# Altium schematic sheet DE-280817.SchDoc(DE-280817)
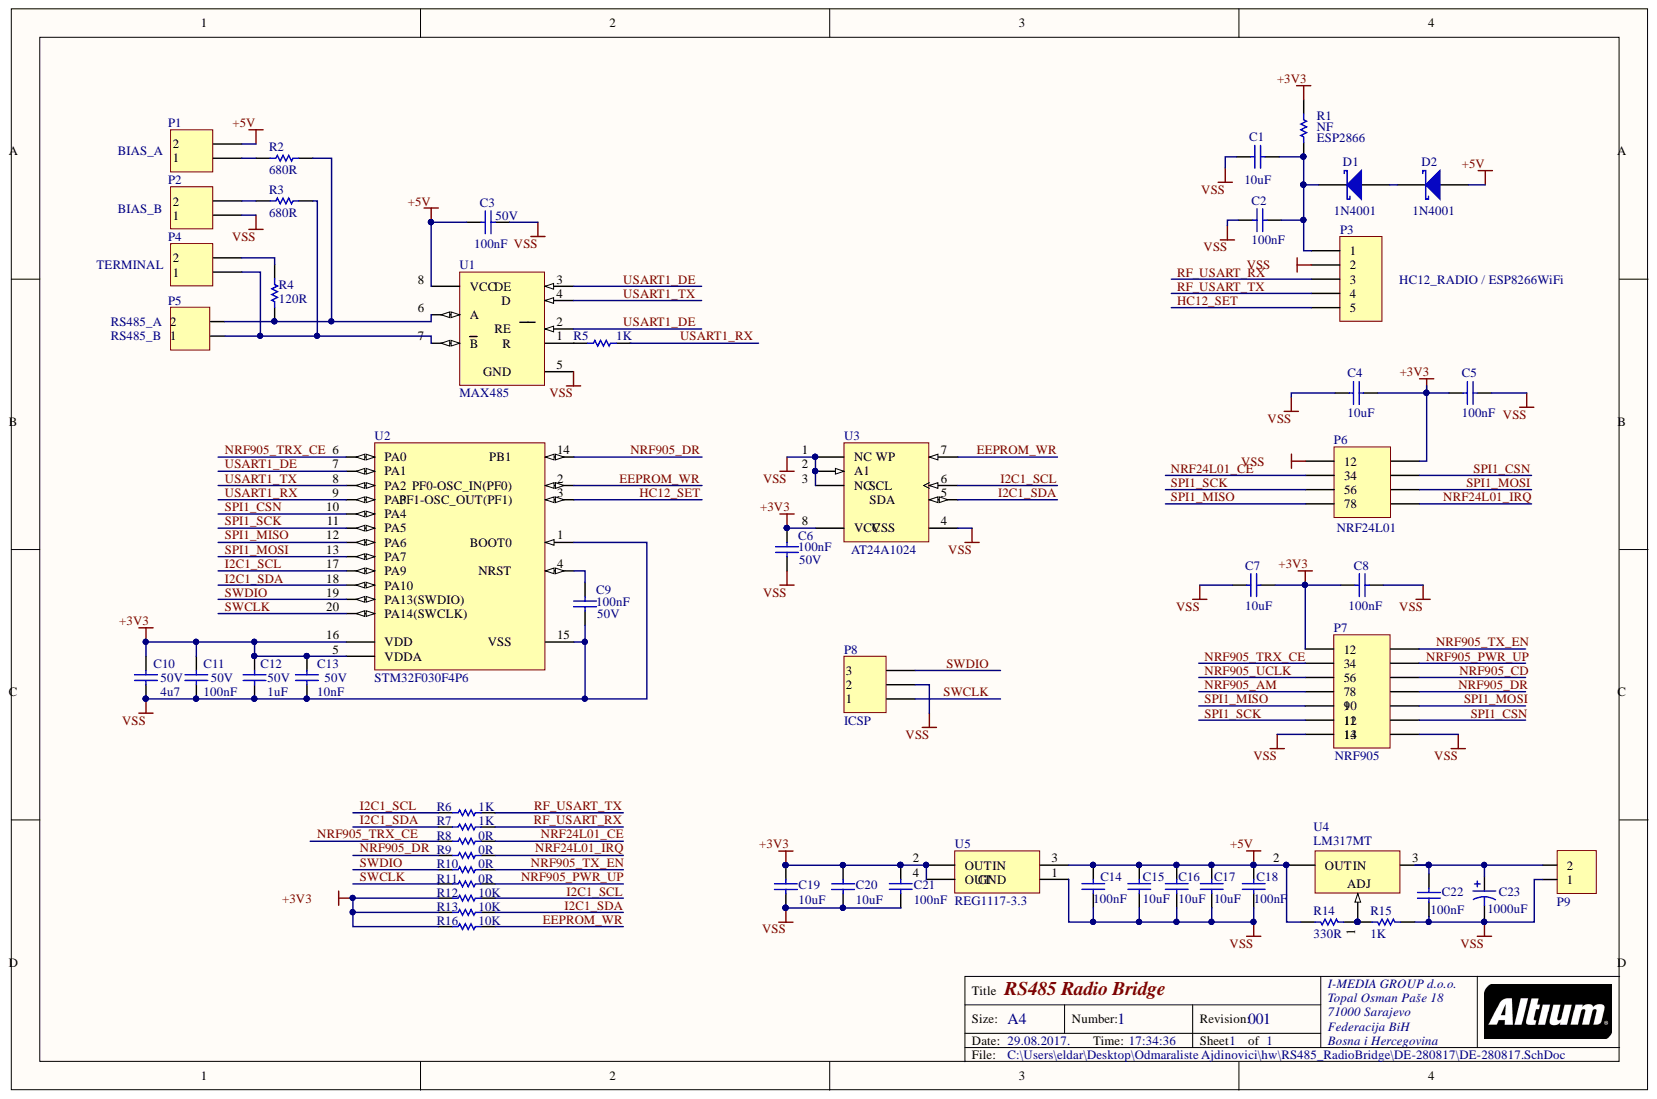
[diagram p0: DE-280817.SchDoc]

## Parts
C1  value 10uF  [0805]  (hidden comment: C0805)
C2  value 100nF  [0603]  (hidden comment: C0603)
C3  50V  value 100nF  [0603]
C4  value 10uF  [0805]  (hidden comment: C0805)
C5  value 100nF  [0603]  (hidden comment: C0603)
C6  50V  value 100nF  [0603]
C7  value 10uF  [0805]  (hidden comment: C0805)
C8  value 100nF  [0603]  (hidden comment: C0603)
C9  50V  value 100nF  [0603]
C10  50V  value 4u7  [0805]
C11  50V  value 100nF  [0603]
C12  50V  value 1uF  [0603]
C13  50V  value 10nF  [0603]
C14  100nF  [0603]
C15  10uF  [0805]
C16  10uF  [0805]
C17  10uF  [0805]
C18  100nF  [0603]
C19  10uF  [0805]
C20  10uF  [0805]
C21  100nF  [0603]
C22  100nF  [0603]
C23  1000uF  [CAPPR5-10x16]
D1  1N4001  [SMA]
D2  1N4001  [SMA]
P1  BIAS_A  [HDR1X2]
P2  BIAS_B  [HDR1X2]
P3  HC12_RADIO / ESP8266WiFi  [HDR1X5]
P4  TERMINAL  [HDR1X2]
P5  TERMINAL BLOK 2  [TERMINAL BLOK 2]  (value not drawn)
P6  NRF24L01  [HDR2X4]
P7  NRF905  [HDR2X7]
P8  ICSP  [HDR1X3]
P9  TERMINAL BLOK 2  [TERMINAL BLOK 2]  (value not drawn)
R1  NF  [ERA-0603]
R2  680R  [ERA-0805]
R3  680R  [ERA-0805]
R4  120R  [ERA-0805]
R5  1K  [ERA-0805]
R6  1K  [ERA-0603]
R7  1K  [ERA-0603]
R8  0R  [ERA-0603]
R9  0R  [ERA-0603]
R10  0R  [ERA-0603]
R11  0R  [ERA-0603]
R12  10K  [ERA-0603]
R13  10K  [ERA-0603]
R14  value 330R  [ERA-0805]  (hidden comment: ERA6Y)
R15  value 1K  [ERA-0805]  (hidden comment: ERA6Y)
R16  10K  [ERA-0603]
U1  MAX485  [D008_L]
U2  STM32F030F4P6  [STM-TSSOP20_N]
U3  AT24A1024  [SOIC-SN8_N]
U4  LM317MT  [221A-06]
U5  REG1117-3.3  [ZZ311]

## Nets
+3V3: C4.1 C5.1 C6.1 C7.1 C8.1 C10.1 C11.1 C12.1 C13.1 C19.2 C20.2 C21.2 P6.2 P7.1 R1.2 R12.2 R13.2 R16.2 U2.5(VDDA) U2.16(VDD) U3.8(VCC) U5.2 U5.4(OUT)
+5V: C3.1 C14.2 C15.2 C16.2 C17.2 C18.2 D2.1 P1.2 R14.1 U1.8(VCC) U4.2 U5.3
VSS: C1.2 C2.2 C3.2 C4.2 C5.2 C6.2 C7.2 C8.2 C9.2 C10.2 C11.2 C12.2 C13.2 C14.1 C15.1 C16.1 C17.1 C18.1 C19.1 C20.1 C21.1 C22.1 C23.2 P2.1 P3.2 P6.1 P7.13 P7.14 P8.2 P9.1 R15.2 U1.5 U2.1 U2.15 U3.1(NC_WP) U3.2(A1) U3.3(NC) U3.4 U5.1
EEPROM_WR: R16.1 U2.2 U3.7
HC12_SET: P3.5 U2.3
I2C1_SCL: R6.2 R12.1 U2.17(PA9) U3.6
I2C1_SDA: R7.2 R13.1 U2.18(PA10) U3.5
NRF24L01_CE: P6.3 R8.1
NRF24L01_IRQ: P6.8 R9.1
NRF905_AM: P7.7
NRF905_CD: P7.6
NRF905_DR: P7.8 R9.2 U2.14
NRF905_PWR_UP: P7.4 R11.1
NRF905_TRX_CE: P7.3 R8.2 U2.6(PA0)
NRF905_TX_EN: P7.2 R10.1
NRF905_UCLK: P7.5
RF_USART_RX: P3.3 R7.1
RF_USART_TX: P3.4 R6.1
SPI1_CSN: P6.4 P7.12 U2.10(PA4)
SPI1_MISO: P6.7 P7.9 U2.12(PA6)
SPI1_MOSI: P6.6 P7.10 U2.13(PA7)
SPI1_SCK: P6.5 P7.11 U2.11(PA5)
SWCLK: P8.1 R11.2 U2.20(PA14(SWCLK))
SWDIO: P8.3 R10.2 U2.19(PA13(SWDIO))
USART1_DE: U1.2 U1.3 U2.7(PA1)
USART1_RX: R5.1 U2.9(PA3)
USART1_TX: U1.4 U2.8(PA2)
NetC1_1: C1.1 C2.1 D1.2 P3.1 R1.1
NetC9_1: C9.1 U2.4
NetC22_2: C22.2 C23.1 P9.2 U4.3
NetD1_1: D1.1 D2.2
NetP1_1: P1.1 R2.2
NetP2_2: P2.2 R3.2
NetP4_1: P4.1 P5.1 R3.1 U1.7(B)
NetP4_2: P4.2 R4.1
NetP5_2: P5.2 R2.1 R4.2 U1.6(A)
NetR5_2: R5.2 U1.1
NetR14_2: R14.2 R15.1 U4.1

## Library data of the parts
C1 C4 C7 C10 C15-C17 C19 C20 = C0805: Ceramic Chip Capacitor - Standard; Note: Footprint corresponds to dimensions for bulk cassette packaging. This case size has tightened tolerances.; Code_IPC: 2012[0805]; Code_JEITA: 0805; Component Kind: Standard; ComponentLink1Description: Manufacturer Link; ComponentLink1URL: http://www.kemet.com/; ComponentLink2Description: Datasheet; ComponentLink2URL: http://www.kemet.com/kemet/web/homepage/kechome.nsf/vapubfilesname/F3102TA.pdf/$file/F3102TA.pdf; DatasheetDocument: Mar-2001; LatestRevisionDate: 19-Mar-2004; LatestRevisionNote: Silkscreen size reduced.; PackageDocument: Mar-2001; PackageReference: 0805; Published: 17-Jul-2002; Signal Integrity: C0805; Simulation: CAP; Publisher: Altium Limited
  C1 C4 C7 C10: Library Name: KEMET Chip Capacitor.IntLib
  C15: Library Name: DE-200216_03.SchLib
  C16 C17 C19 C20: Library Name: DE-251215.SchLib
C2 C3 C5 C6 C8 C9 C11-C14 C18 C21 C22 = C0603: Ceramic Chip Capacitor - Standard; Note: Footprint corresponds to dimensions for bulk cassette packaging. This case size has tightened tolerances.; Code_IPC: 1608 [0603]; Code_JEITA: 0603; Component Kind: Standard; ComponentLink1Description: Manufacturer Link; ComponentLink1URL: http://www.kemet.com/; ComponentLink2Description: Datasheet; ComponentLink2URL: http://www.kemet.com/kemet/web/homepage/kechome.nsf/vapubfilesname/F3102TA.pdf/$file/F3102TA.pdf; DatasheetDocument: Mar-2001; LatestRevisionDate: 19-Mar-2004; LatestRevisionNote: Silkscreen size reduced.; PackageDocument: Mar-2001; PackageReference: 0603; Published: 17-Jul-2002; Signal Integrity: C0603; Simulation: CAP; Publisher: Altium Limited
  C2 C3 C5 C6 C8 C9 C11-C13: Library Name: KEMET Chip Capacitor.IntLib
  C14 C18 C21: Library Name: DE-251215.SchLib
  C22: Library Name: DE-251215.SchLib; Value: 100nF
C23 = EKA00DD410D00: Aluminum Electrolytic Capacitor, Radial Style with Long Leads: 1000uF, 16V; DrillSize: Nominal, Rounded To The Nearest 0.1mm; Note: Refer to Manufacturer's Data Sheets for other lead formations; Component Kind: Standard; ComponentLink1Description: Manufacturer Link; ComponentLink1URL: http://www.vishay.com/; ComponentLink2Description: Datasheet; ComponentLink2URL: http://www.vishay.com/docs/25014/eka.pdf; DatasheetDocument: 20-Feb-2001; PackageReference: CAPPR5-10X16; Published: 19-Mar-2004; Value: 1000uF; Library Name: Vishay Roederstein Electrolytic Radial-Lead Capacitor.IntLib; Publisher: Altium Limited
D1 D2 = 10MQ060N: Schottky Rectifier; Component Kind: Standard; ComponentLink1Description: Manufacturer Link; ComponentLink1URL: http://www.irf.com/; ComponentLink2Description: Datasheet; ComponentLink2URL: http://www.irf.com/product-info/datasheets/data/10MQ060N.pdf; DatasheetVersion: May-2002; Library Name: IR Rectifier - Schottky.IntLib; PackageDescription: DO-214-AC/SMA; 2 C-Bend Leads; Body 5 x 2.7 mm, inc. leads (LxW); PackageReference: SMA; PackageVersion: revH, May-2002; Published: 17-Sep-2003; Simulation: 10MQ060N; Publisher: Altium Limited
P1 P2 P4 = Header 2: Header, 2-Pin; Component Kind: Standard; LatestRevisionDate: 17-Jul-2002; LatestRevisionNote: Re-released for DXP Platform.; Library Name: Miscellaneous Connectors.IntLib; Signal Integrity: Connector; Publisher: Altium Limited
P3 = Header 5: Header, 5-Pin; Component Kind: Standard; LatestRevisionDate: 17-Jul-2002; LatestRevisionNote: Re-released for DXP Platform.; Library Name: Miscellaneous Connectors.IntLib; Signal Integrity: Connector; Publisher: Altium Limited
P5 P9 = TERMINAL BLOK 2: 2 pinski terminal blok; Component Kind: Standard
  P5: Library Name: DE-280817.SchLib
  P9: Library Name: DE-130817.SchLib
P6 = Header 4X2: Header, 4-Pin, Dual row; Component Kind: Standard; LatestRevisionDate: 17-Jul-2002; LatestRevisionNote: Re-released for DXP Platform.; Library Name: Miscellaneous Connectors.IntLib; Signal Integrity: Connector; Publisher: Altium Limited
P7 = Header 7X2: Header, 7-Pin, Dual row; Component Kind: Standard; LatestRevisionDate: 17-Jul-2002; LatestRevisionNote: Re-released for DXP Platform.; Library Name: Miscellaneous Connectors.IntLib; Signal Integrity: Connector; Publisher: Altium Limited
P8 = Header 3: Header, 3-Pin; Component Kind: Standard; LatestRevisionDate: 17-Jul-2002; LatestRevisionNote: Re-released for DXP Platform.; Library Name: Miscellaneous Connectors.IntLib; Signal Integrity: Connector; Publisher: Altium Limited
R1 R6-R13 R16 = ERAV: Metal Film Thermosensitive Chip Resistor, 0.56 Ohm to 10k Ohm Range, 5% Tolerance, 0603 Size, 0.063 W; Note: If flow soldering, adjust pad width of PCB Footprint to 0.7 - 0.8 times the width of the resistor.; Code_IPC: 1608 [0603]; Component Kind: Standard; ComponentLink1Description: Manufacturer Link; ComponentLink1URL: http://www.panasonic.com/industrial/components/resistive_products.htm; ComponentLink2Description: Datasheet; ComponentLink2URL: http://www.panasonic.com/industrial/components/pdf/AOF0000CE1.pdf; LatestRevisionDate: 19-Mar-2004; LatestRevisionNote: Silkscreen size reduced.; PackageDocument: Mar-2003; PackageReference: ERA-0603; Published: 17-Dec-2003; Signal Integrity: Res; Simulation: RESISTOR; Publisher: Altium Limited
  R1: Library Name: DE-200216_03.SchLib
  R6-R13 R16: Library Name: DE-180417.SchLib
R2-R5 R14 R15 = ERA6Y: Rectangular Thick Film Chip Resistor, 10 Ohm to 1M Ohm Range, 0.1% and 0.5% Tolerance, 0805 Size, 0.1 W; Note: If flow soldering, adjust pad width of PCB Footprint to 0.7 - 0.8 times the width of the resistor.; Code_IPC: 2012 [0805]; Component Kind: Standard; ComponentLink1Description: Manufacturer Link; ComponentLink1URL: http://www.panasonic.com/industrial/components/resistive_products.htm; ComponentLink2Description: Datasheet; ComponentLink2URL: http://www.panasonic.com/industrial/components/pdf/AOA0000CE8.pdf; LatestRevisionDate: 19-Mar-2004; LatestRevisionNote: Silkscreen size reduced.; PackageDocument: Mar-2003; PackageReference: ERA-0805; Published: 17-Dec-2003; Signal Integrity: Res; Simulation: RESISTOR; Publisher: Altium Limited
  R2-R5: Library Name: DE-280817.SchLib
  R14 R15: Library Name: Panasonic Resistor.IntLib
U1 = SN75176BD: Differential Bus Transceiver; Note: Receiver Hysteresis of 50mV Typical; Code_IPC: SOIC127P600-8; Component Kind: Standard; ComponentLink1Description: Manufacturer Link; ComponentLink1URL: http://www.ti.com/; ComponentLink2Description: Datasheet; ComponentLink2URL: http://www-s.ti.com/sc/ds/sn75176b.pdf; DatasheetVersion: Sep-1989; LatestRevisionDate: 13-Apr-2006; LatestRevisionNote: IPC-7351 Footprint Added.; Library Name: DE-280817.SchLib; PackageDescription: 8-Pin Small Outline Integrated Circuit 1.27 mm Pitch; PackageReference: D008; PackageVersion: Jan-1998; PCB3D: D008; Published: 15-May-2002; Publisher: Altium Limited
U2 = STM32F030F4P6: ARM Cortex-M0 32-bit MCU, 16 KB Flash, 4 KB Internal RAM, 15 I/Os, 20-pin TSSOP, -40 to 85 degC, Tube; FLASH Size (Prog) (kB): 16; I/Os (High Current): 15; Internal RAM Size (kB): 4; Operating Frequency(F) (Processor speed) (MHz): 48; Supply Voltage(Vcc) min (V): 2.4; Component Kind: Standard; ComponentLink1Description: Manufacturer URL; ComponentLink1URL: http://www.st.com/web/en/home.html; ComponentLink2Description: Datasheet; ComponentLink2URL: http://www.st.com/st-web-ui/static/active/en/resource/technical/document/datasheet/DM00088500.pdf; ComponentLink3Description: Package Specification; ComponentLink3URL: http://www.st.com/st-web-ui/static/active/en/resource/technical/document/datasheet/DM00065136.pdf; Core: ARM Cortex-M0; DatasheetVersion: Rev. 1; Library Name: STMicroelectronics STM32 F0.IntLib; Mounting Technology: Surface Mount; PackageDescription: 20-Pin Thin Shrink Small Outline Package, 6.5 x 4.4 mm Body, 0.65 mm Pitch; PackageReference: TSSOP20; PackageVersion: Rev. 1, 11/2012; Packing: Tube; PartNumber: STM32F030F4P6; Supplier 1: Digi-Key; Supplier 2: Newark; Supplier 3: Mouser; Supplier Part Number 1: 497-14044-5-ND; Supplier Part Number 2: 06X3648; Supplier Part Number 3: 511-STM32F030F4P6
U3 = 24AA64/SN: 64Kbit, 400kHz, 1.7V, I2C Serial EEPROM, 8-Pin SOIC 150mil, Commercial Temperature; Code_IPC: SOIC127P600-8; Code_JEDEC: MS-012; Component Kind: Standard; ComponentLink1Description: Datasheet; ComponentLink1URL: http://ww1.microchip.com/downloads/en/DeviceDoc/21189Q.pdf; ComponentLink2Description: Packaging Specification; ComponentLink2URL: http://www.microchip.com/stellent/groups/techpub_sg/documents/packagingspec/en012702.pdf; ComponentLink3Description: Microchip.com Home; ComponentLink3URL: http://www.microchip.com/; DatasheetVersion: revQ, Jun-2009; Library Name: DE-280817.SchLib; PackageDescription: 8-Lead Plastic Small Outline (SN) - Narrow, 3.90mm Body [SOIC]; PackageReference: SOIC-SN8; PackageVersion: revBB, Aug-2009; Published: 29-Jan-2009; Supplier 1: Digi-Key; Supplier Part Number 1: 24AA64/SN-ND; Publisher: Altium Limited
U4 = LM317MT: Three-Terminal Adjustable Output Positive Voltage Regulator; Note: Heatsink surface connected to Pin 2; Code_JEDEC: TO-262-AA; Component Kind: Standard; ComponentLink1Description: Manufacturer Link; ComponentLink1URL: http://www.onsemi.com/site/products/taxonomy/0,4438,503,00.html; ComponentLink2Description: Datasheet; ComponentLink2URL: http://www.onsemi.com/pub/Collateral/LM317M-D.PDF; DatasheetVersion: Nov-1999; Library Name: ON Semi Power Mgt Voltage Regulator.IntLib; PackageDescription: TO, Thru-Hole, Vertical, Heatsink Mounted; 3 In-Line Leads; Pitch 2.54 mm; PackageReference: 221A-06; PackageVersion: 1997; Published: 15-Apr-2002; Publisher: Altium Limited
U5 = REG1117-3.3: 800mA and 1A Low Dropout Positive Regulator 2.85V, 3.3V, 5V, and Adjustable; Component Kind: Standard; ComponentLink1Description: Manufacturer Link; ComponentLink1URL: http://www.ti.com/; ComponentLink2Description: Datasheet; ComponentLink2URL: http://www-s.ti.com/sc/ds/REG1117.pdf; DatasheetVersion: Sep-1997; LatestRevisionDate: 17-Jul-2002; LatestRevisionNote: Re-released for DXP Platform.; Library Name: DE-251215.SchLib; PackageDescription: SOT-223/SC-73; 4 Leads; Body 6.7 x 7.3 mm, inc. leads (LxW max); PackageReference: ZZ311; PackageVersion: revC; Published: 8-Jun-2000; Publisher: Altium Limited
